# Altium schematic sheet MCU.SchDoc
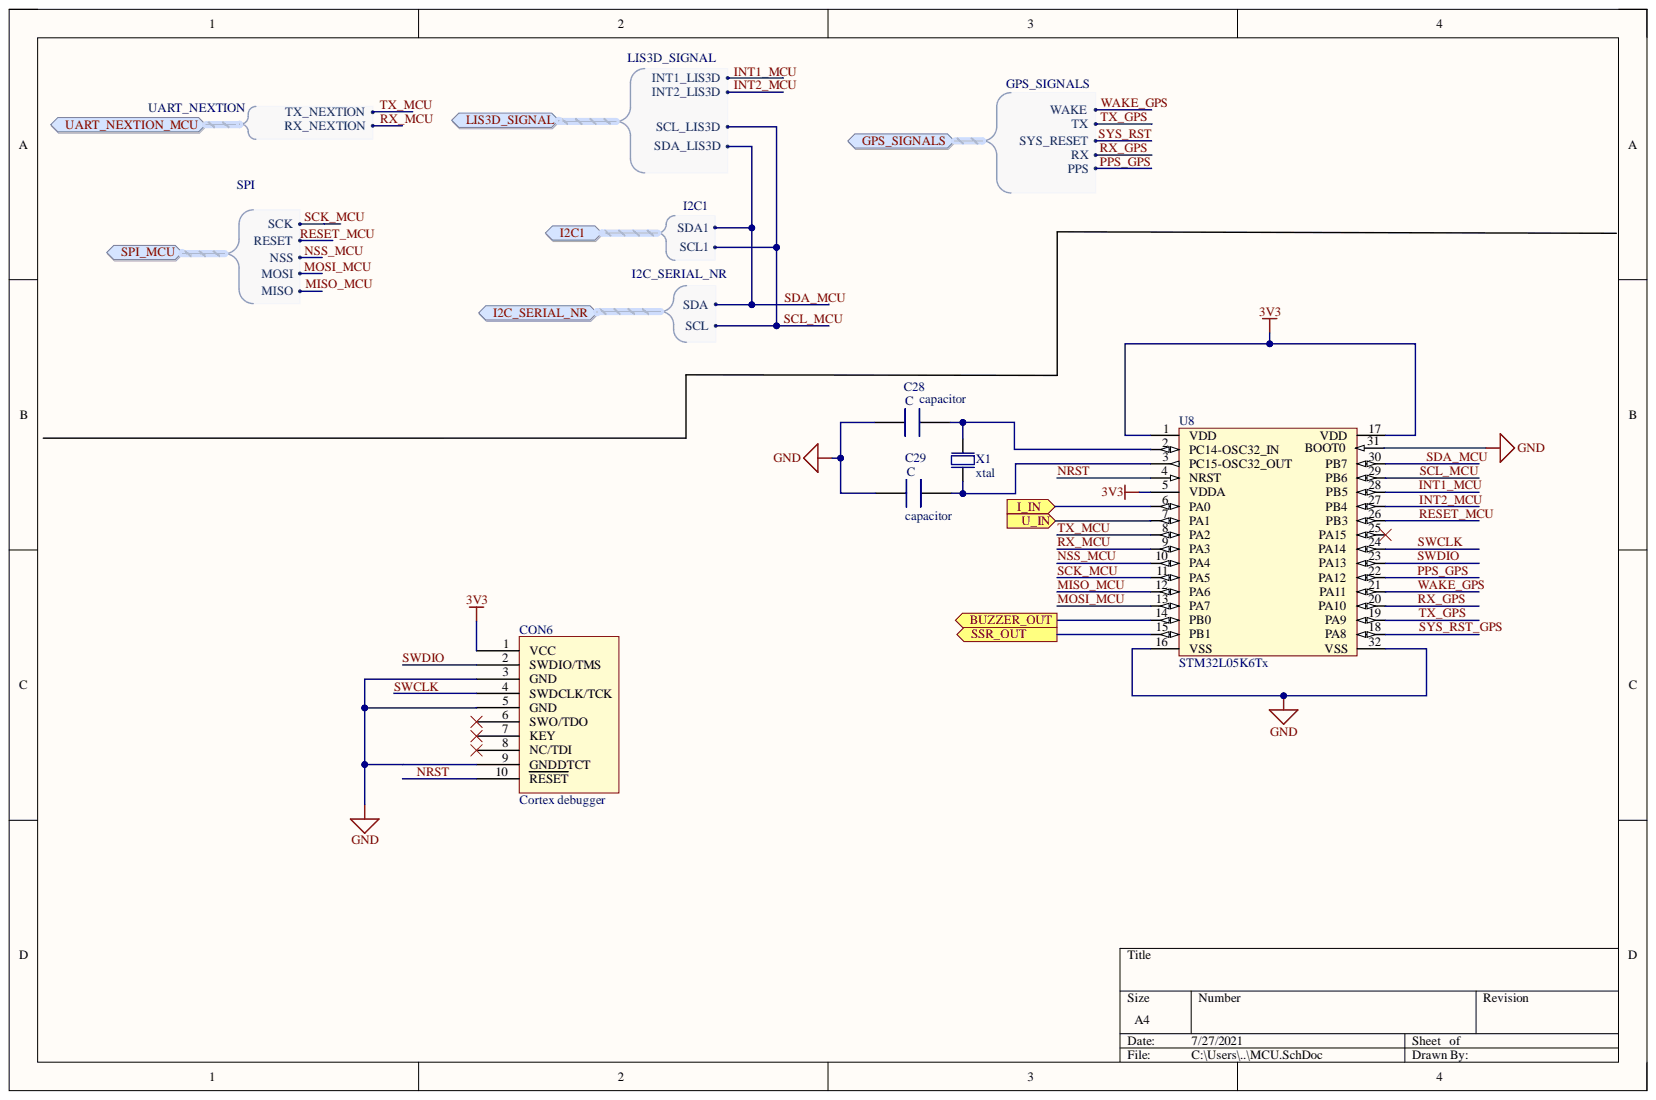
[diagram p0: MCU.SchDoc]

## Parts
C28  capacitor  [CAPC1005X04L]
C29  capacitor  [CAPC1005X04L]
CON6  Cortex debugger  [Jtag header]
U8  STM32L05K6Tx
X1  xtal  [BT-XTAL_2016]

## Ports
BUZZER_OUT, GPS_SIGNALS, GPS_SIGNALS.PPS, GPS_SIGNALS.RX, GPS_SIGNALS.SYS_RESET, GPS_SIGNALS.TX, GPS_SIGNALS.WAKE, I2C1, I2C1.SCL1, I2C1.SDA1, I2C_SERIAL_NR, I2C_SERIAL_NR.SCL, I2C_SERIAL_NR.SDA, I_IN, LIS3D_SIGNAL, LIS3D_SIGNAL.INT1_LIS3D, LIS3D_SIGNAL.INT2_LIS3D, LIS3D_SIGNAL.SCL_LIS3D, LIS3D_SIGNAL.SDA_LIS3D, SPI_MCU, SPI_MCU.MISO, SPI_MCU.MOSI, SPI_MCU.NSS, SPI_MCU.RESET, SPI_MCU.SCK, SSR_OUT, U_IN, UART_NEXTION_MCU, UART_NEXTION_MCU.RX_NEXTION, UART_NEXTION_MCU.TX_NEXTION

## Nets
3V3: CON6.1(VCC) U8.1(VDD) U8.5(VDDA) U8.17(VDD)
GND: C28.2 C29.2 CON6.3(GND) CON6.5(GND) CON6.9(GNDDTCT) U8.16(VSS) U8.31(BOOT0) U8.32(VSS)
BUZZER_OUT: U8.14(PB0) ; port BUZZER_OUT
I_IN: U8.6(PA0) ; port I_IN
INT1_MCU: U8.28(PB5)
INT2_MCU: U8.27(PB4)
MISO_MCU: U8.12(PA6) ; port SPI_MCU
MOSI_MCU: U8.13(PA7) ; port SPI_MCU
NRST: CON6.10(RESET) U8.4(NRST)
NSS_MCU: U8.10(PA4) ; port SPI_MCU
PPS_GPS: U8.22(PA12) ; port GPS_SIGNALS
RESET_MCU: U8.26(PB3) ; port SPI_MCU
RX_GPS: U8.20(PA10) ; port GPS_SIGNALS
RX_MCU: U8.9(PA3)
SCK_MCU: U8.11(PA5) ; port SPI_MCU
SCL_MCU: U8.29(PB6) ; port I2C1, port I2C_SERIAL_NR, port LIS3D_SIGNAL
SDA_MCU: U8.30(PB7) ; port I2C1, port I2C_SERIAL_NR, port LIS3D_SIGNAL
SSR_OUT: U8.15(PB1) ; port SSR_OUT
SWCLK: CON6.4(SWDCLK/TCK) U8.24(PA14)
SWDIO: CON6.2(SWDIO/TMS) U8.23(PA13)
SYS_RST_GPS: U8.18(PA8)
TX_GPS: U8.19(PA9) ; port GPS_SIGNALS
TX_MCU: U8.8(PA2)
U_IN: U8.7(PA1) ; port U_IN
WAKE_GPS: U8.21(PA11) ; port GPS_SIGNALS
NetC28_1: C28.1 U8.2(PC14-OSC32_IN) X1.3
NetC29_1: C29.1 U8.3(PC15-OSC32_OUT) X1.1
NetCON6_6: CON6.6(SWO/TDO)
NetCON6_7: CON6.7(KEY)
NetCON6_8: CON6.8(NC/TDI)
NetU8_25: U8.25(PA15)
N00001: ; port UART_NEXTION_MCU
N00002: ; port UART_NEXTION_MCU
N00003: ; port LIS3D_SIGNAL
N00004: ; port LIS3D_SIGNAL
SYS_RST: ; port GPS_SIGNALS

## Library data of the parts
C28 C29 = capacitor: Component Kind: Standard; Library Name: pasives.SchLib
CON6 = Cortex debugger: Component Kind: Standard; Library Name: conector's_and_TP.SchLib
U8 = STM32L05K6Tx: Component Kind: Standard; Library Name: halfgelijders.SchLib
X1 = xtal: Component Kind: Standard; Library Name: pasives.SchLib
